# Altium schematic sheet Sheet2.SchDoc
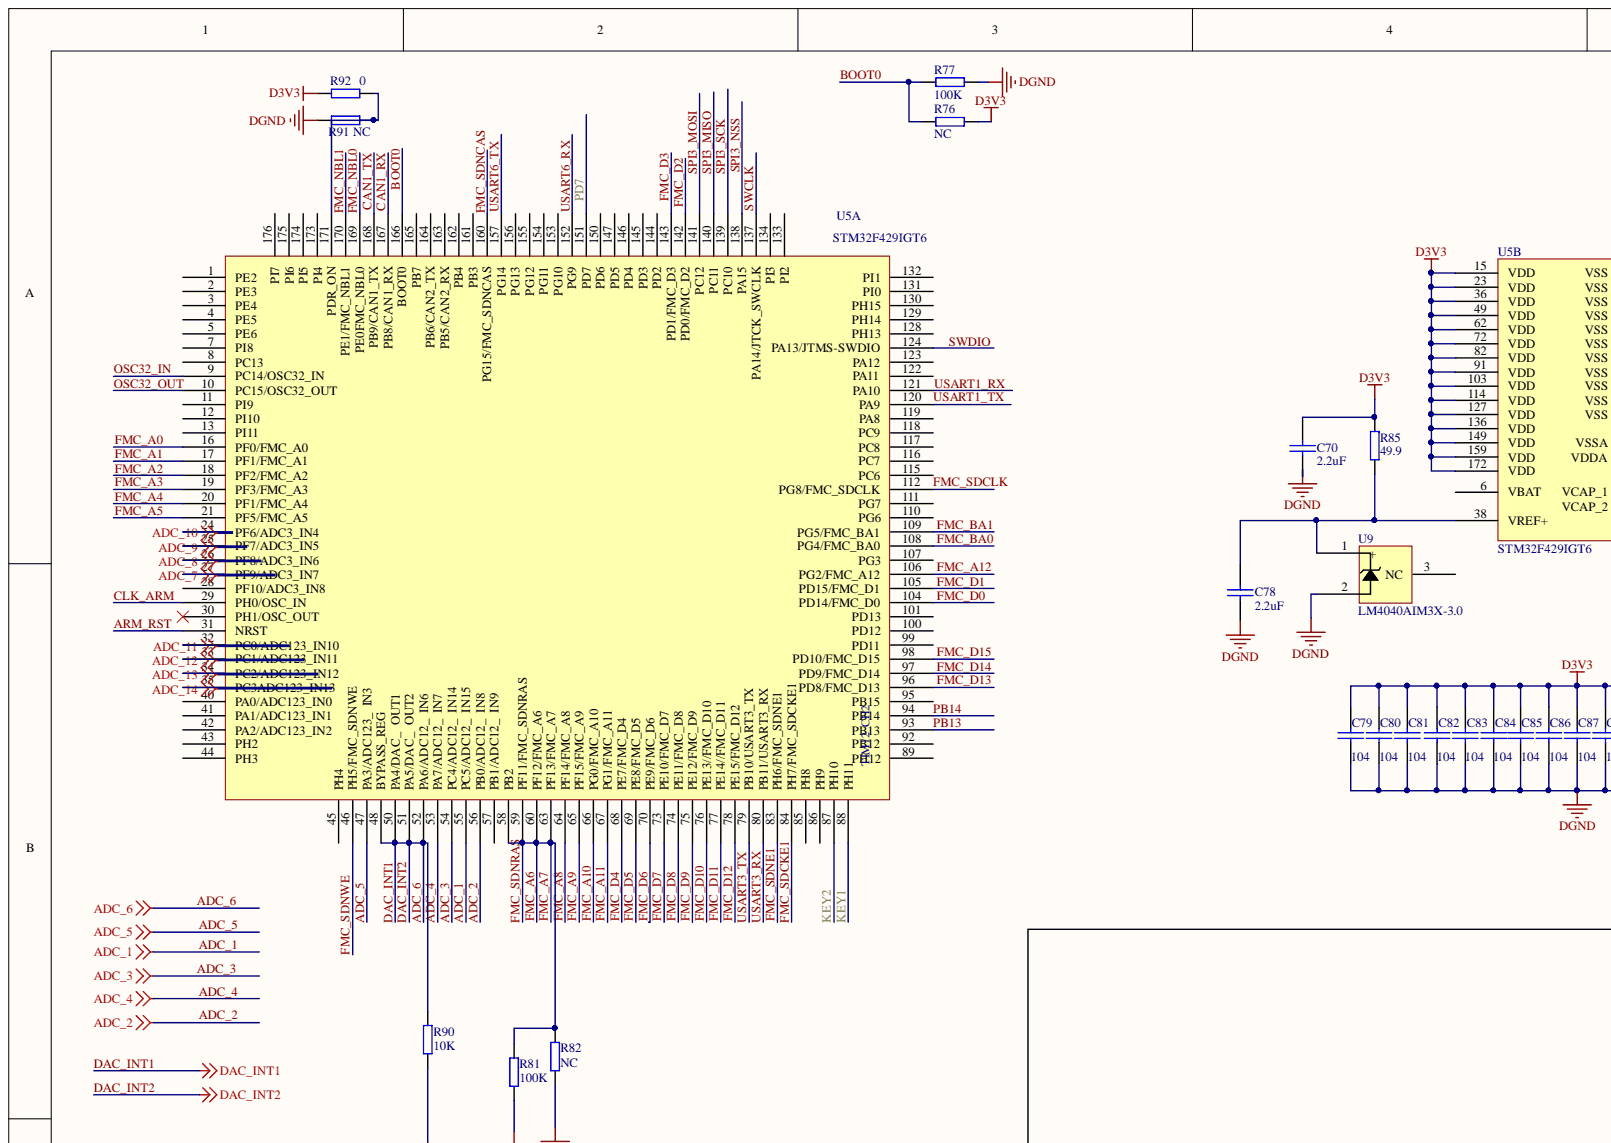
[diagram p0: Sheet2.SchDoc, top left]
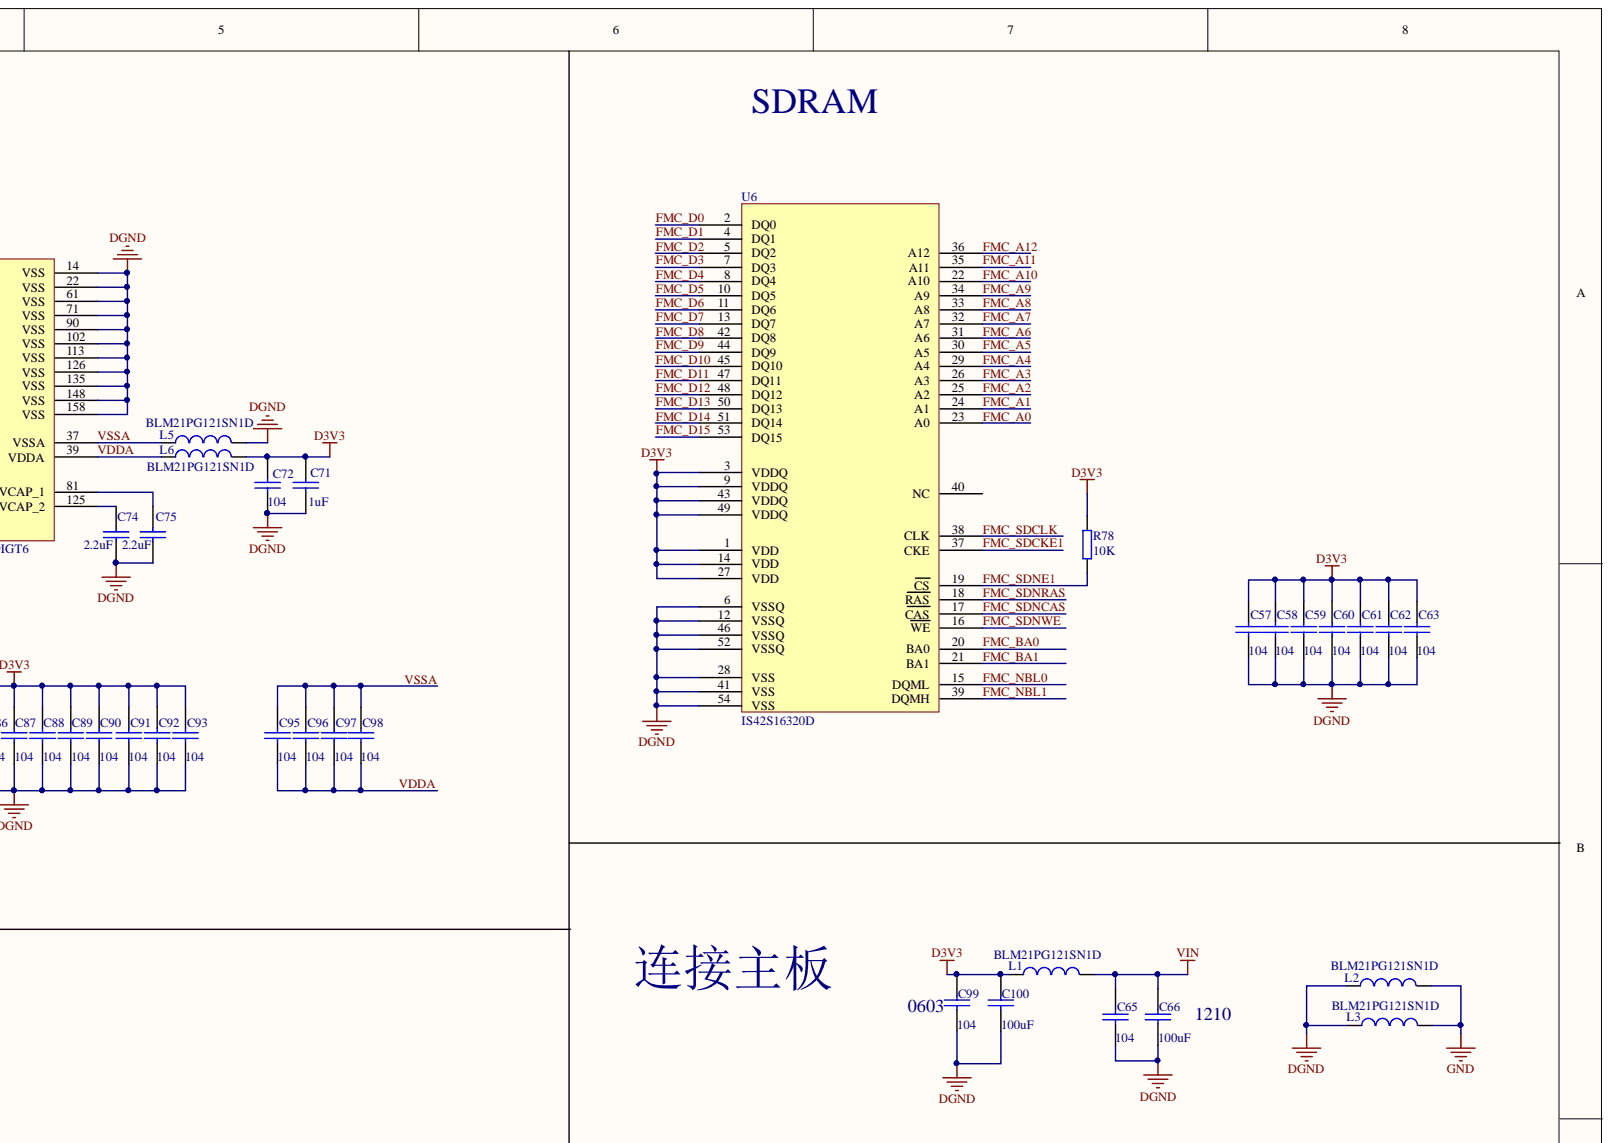
[diagram p1: Sheet2.SchDoc, top right]
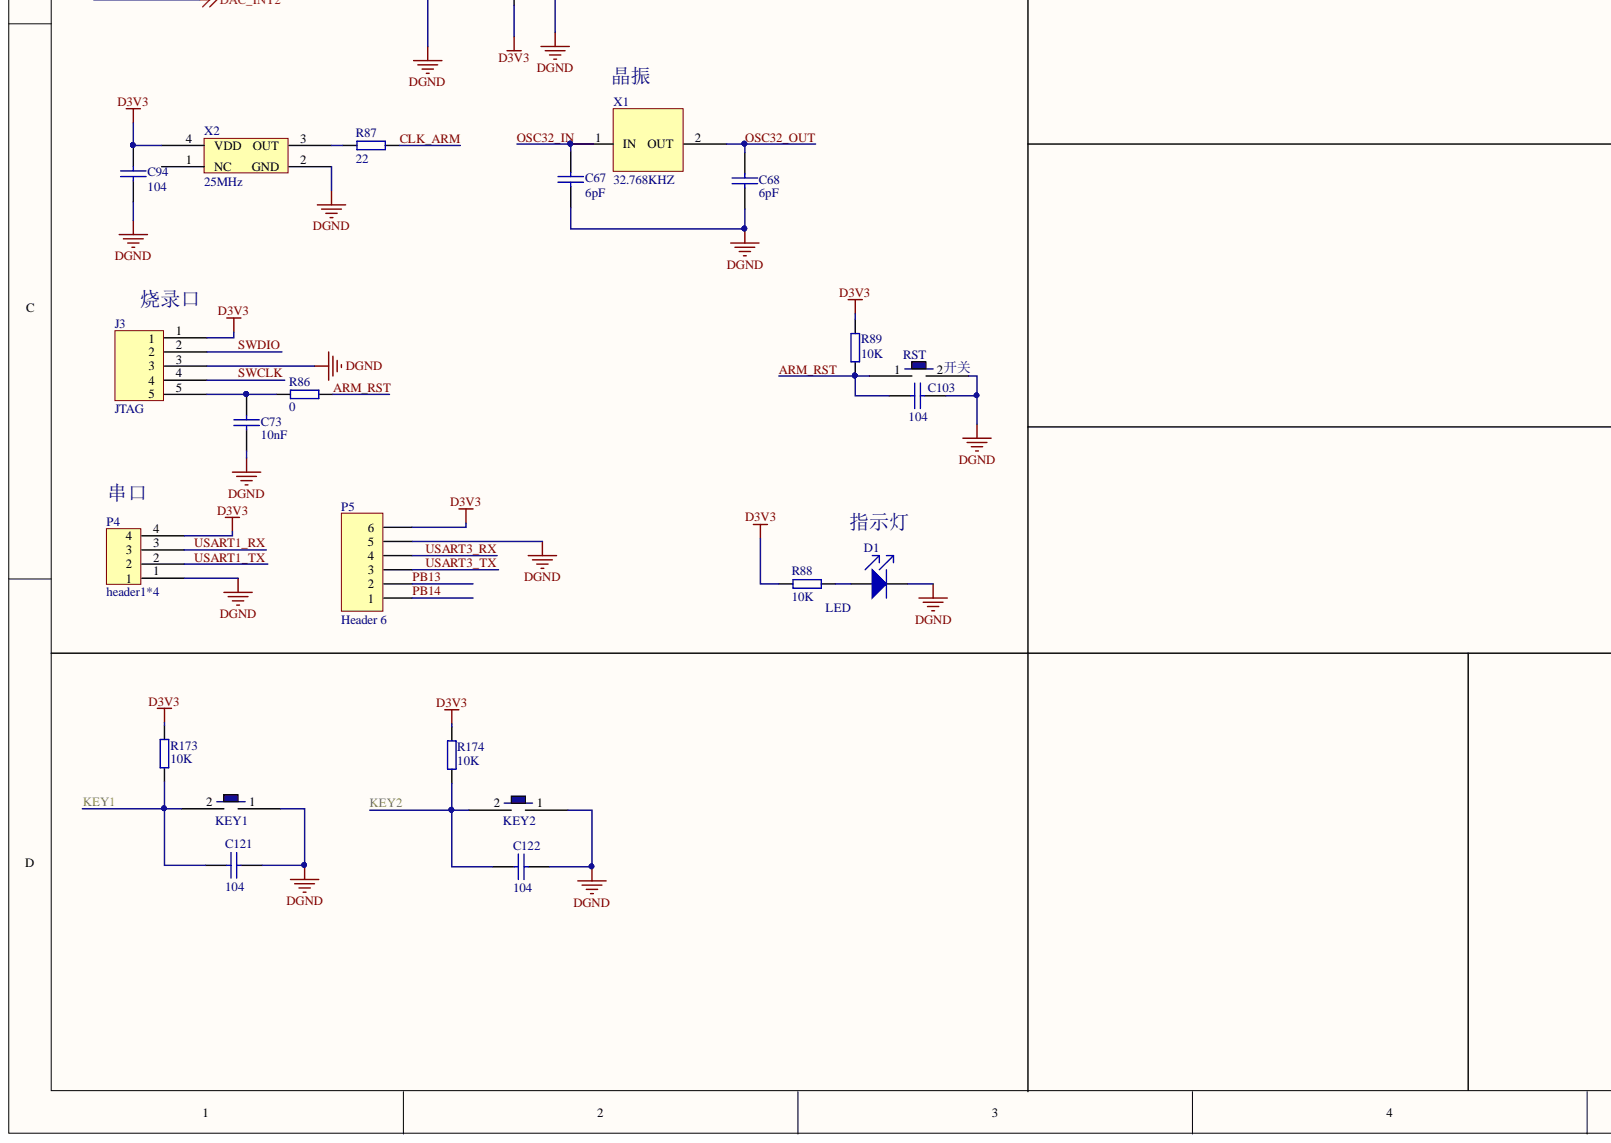
[diagram p2: Sheet2.SchDoc, bottom left]
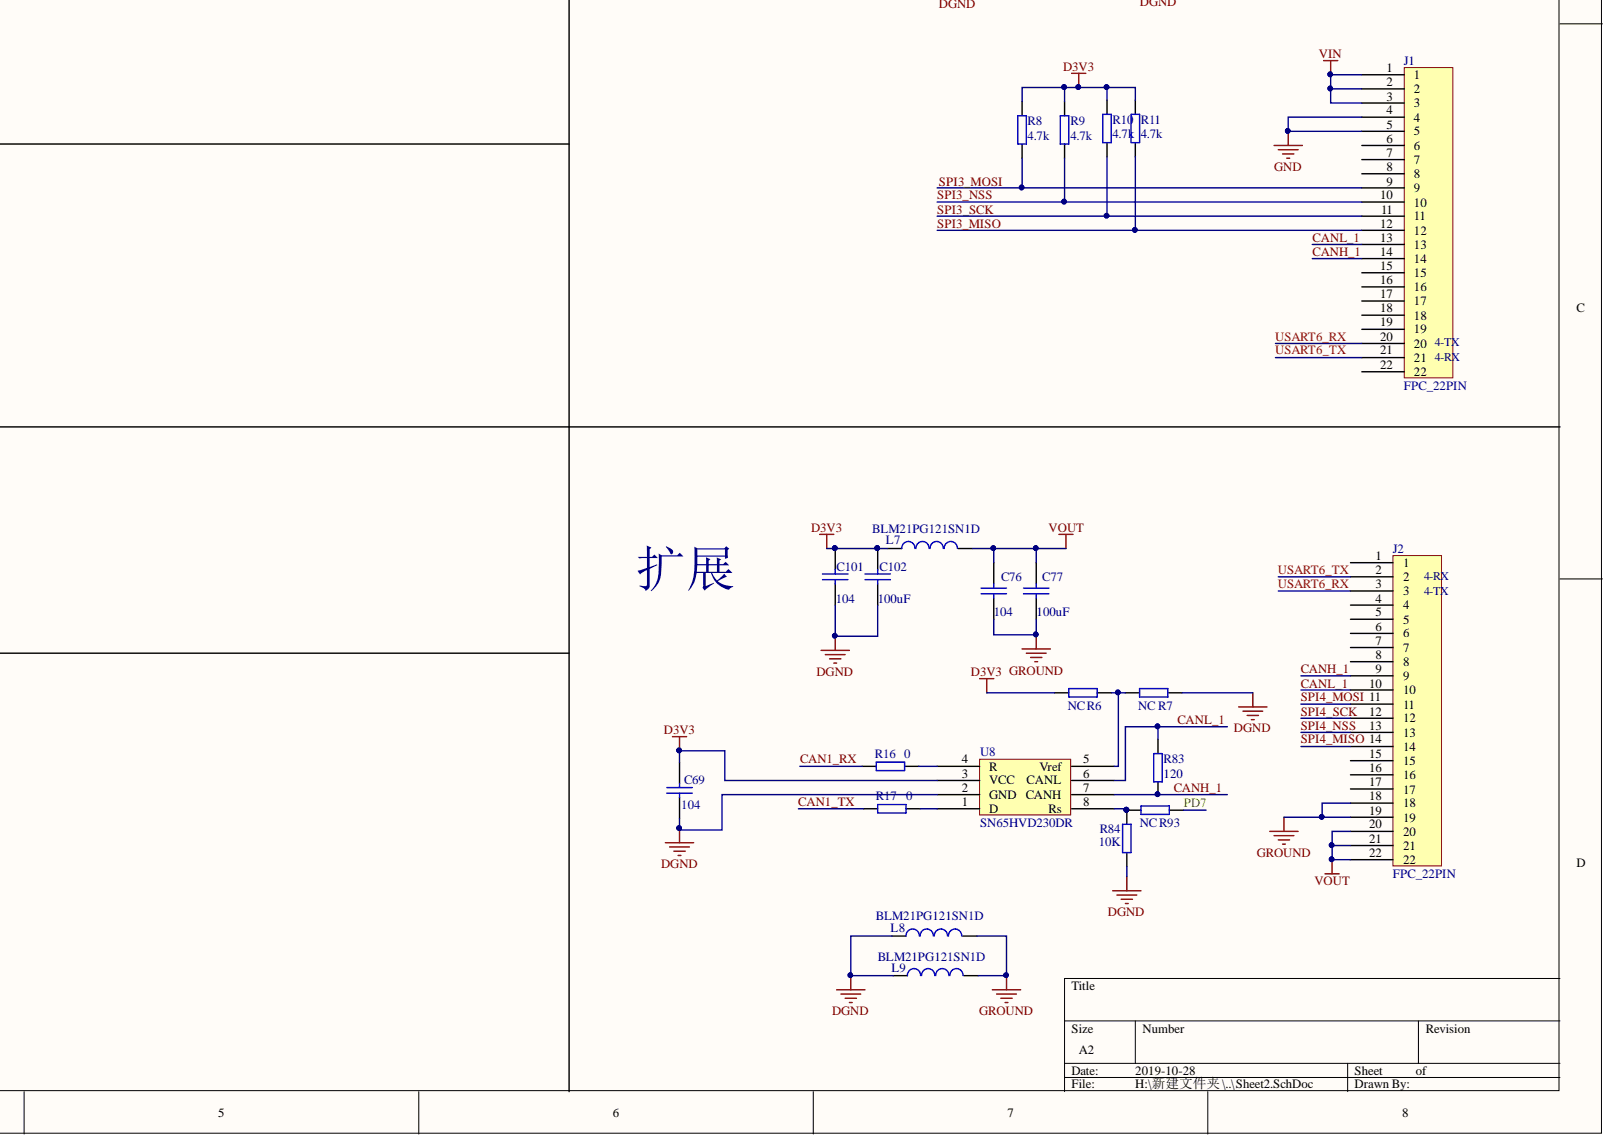
[diagram p3: Sheet2.SchDoc, bottom right]

## Parts
C57  104  [0402_b]
C58  104  [0402_b]
C59  104  [0402_b]
C60  104  [0402_b]
C61  104  [0402_b]
C62  104  [0402_b]
C63  104  [0402_b]
C65  104  [0805]
C66  100uF  [1210]
C67  6pF  [0402_b]
C68  6pF  [0402_b]
C69  104  [0402_b]
C70  2.2uF  [0402_b]
C71  1uF  [0402_b]
C72  104  [0402_b]
C73  10nF  [0402_b]
C74  2.2uF  [0402_b]
C75  2.2uF  [0402_b]
C76  104  [0805]
C77  100uF  [1210]
C78  2.2uF  [0402_b]
C79  104  [0402_b]
C80  104  [0402_b]
C81  104  [0402_b]
C82  104  [0402_b]
C83  104  [0402_b]
C84  104  [0402_b]
C85  104  [0402_b]
C86  104  [0402_b]
C87  104  [0402_b]
C88  104  [0402_b]
C89  104  [0402_b]
C90  104  [0402_b]
C91  104  [0402_b]
C92  104  [0402_b]
C93  104  [0402_b]
C94  104  [0402_b]
C95  104  [0402_b]
C96  104  [0402_b]
C97  104  [0402_b]
C98  104  [0603_b]
C99  104  [0805]
C100  100uF  [1210]
C101  104  [0805]
C102  100uF  [1210]
C103  104  [0402_b]
C121  104  [0402_b]
C122  104  [0402_b]
D1  LED  [0603_b]
J1  FPC_22PIN  [FPC]
J2  FPC_22PIN  [FPC]
J3  JTAG  [XH2.54_5卧插]
KEY1  开关  [key]  (value not drawn)
KEY2  开关  [key]  (value not drawn)
L1  BLM21PG121SN1D  [1231AS-H-100M=P3]
L2  BLM21PG121SN1D  [0805]
L3  BLM21PG121SN1D  [0805]
L5  BLM21PG121SN1D  [0805]
L6  BLM21PG121SN1D  [0805]
L7  BLM21PG121SN1D  [1231AS-H-100M=P3]
L8  BLM21PG121SN1D  [0805]
L9  BLM21PG121SN1D  [0805]
P4  header1*4  [HEADER1-4]
P5  Header 6  [HDR1X6]
R6  NC  [0402_b]
R7  NC  [0402_b]
R8  4.7k  [0402_b]
R9  4.7k  [0402_b]
R10  4.7k  [0402_b]
R11  4.7k  [0402_b]
R16  0  [0402_b]
R17  0  [0402_b]
R76  NC  [0402_b]
R77  100K  [0402_b]
R78  10K  [0402_b]
R81  100K  [0402_b]
R82  NC  [0402_b]
R83  120  [0402_b]
R84  10K  [0402_b]
R85  49.9  [0402_b]
R86  0  [0402_b]
R87  22  [0402_b]
R88  10K  [0402_b]
R89  10K  [0402_b]
R90  10K  [0402_b]
R91  NC  [0402_b]
R92  0  [0402_b]
R93  NC  [0402_b]
R173  10K  [0402_b]
R174  10K  [0402_b]
RST  开关  [key]
U5  STM32F429IGT6  [LQFP176 - duplicate]  (parts A,B)
U6  IS42S16320D  [TSOP54]
U8  SN65HVD230DR  [SOP8]
U9  LM4040AIM3X-3.0  [SOT23_3PIN]
X1  32.768KHZ  [1210_B]
X2  25MHz  [XTL_4PIN_5032]

## Nets
ADC_7: U5.27(PF9/ADC3_IN7)
ADC_8: U5.26(PF8/ADC3_IN6)
ADC_9: U5.25(PF7/ADC3_IN5)
ADC_10: U5.24(PF6/ADC3_IN4)
ADC_11: U5.32(PC0/ADC123_IN10)
ADC_12: U5.33(PC1/ADC123_IN11)
ADC_13: U5.34(PC2/ADC123_IN12)
ADC_14: U5.35(PC3ADC123_IN13)
D3V3: C57.2 C58.2 C59.2 C60.2 C61.2 C62.2 C63.2 C69.2 C70.2 C71.2 C72.2 C79.2 C80.2 C81.2 C82.2 C83.2 C84.2 C85.2 C86.2 C87.2 C88.2 C89.2 C90.2 C91.2 C92.2 C93.2 C94.2 C99.2 C100.2 C101.2 C102.2 J3.1 L1.1 L6.2 L7.1 P4.4 P5.6 R6.2 R8.2 R9.2 R10.2 R11.2 R76.1 R78.1 R81.2 R85.1 R88.2 R89.1 R92.2 R173.1 R174.1 U5.15(VDD) U5.23(VDD) U5.36(VDD) U5.49(VDD) U5.62(VDD) U5.72(VDD) U5.82(VDD) U5.91(VDD) U5.103(VDD) U5.114(VDD) U5.127(VDD) U5.136(VDD) U5.149(VDD) U5.159(VDD) U5.172(VDD) U6.1(VDD) U6.3(VDDQ) U6.9(VDDQ) U6.14(VDD) U6.27(VDD) U6.43(VDDQ) U6.49(VDDQ) U8.3(VCC) X2.4(VDD)
DGND: C57.1 C58.1 C59.1 C60.1 C61.1 C62.1 C63.1 C65.1 C66.1 C67.1 C68.1 C69.1 C70.1 C71.1 C72.1 C73.1 C74.1 C75.1 C78.1 C79.1 C80.1 C81.1 C82.1 C83.1 C84.1 C85.1 C86.1 C87.1 C88.1 C89.1 C90.1 C91.1 C92.1 C93.1 C94.1 C99.1 C100.1 C101.1 C102.1 C103.2 C121.1 C122.1 D1.2 J3.3 KEY1.1 KEY2.1 L2.1 L3.1 L5.2 L8.1 L9.1 P4.1 P5.5 R7.1 R77.1 R82.2 R84.2 R90.2 R91.2 RST.2 U5.14(VSS) U5.22(VSS) U5.61(VSS) U5.71(VSS) U5.90(VSS) U5.102(VSS) U5.113(VSS) U5.126(VSS) U5.135(VSS) U5.148(VSS) U5.158(VSS) U6.6(VSSQ) U6.12(VSSQ) U6.28(VSS) U6.41(VSS) U6.46(VSSQ) U6.52(VSSQ) U6.54(VSS) U8.2(GND) U9.2 X2.2(GND)
GND: J1.4 J1.5 L2.2 L3.2
GROUND: C76.1 C77.1 J2.18 J2.19 L8.2 L9.2
VIN: C65.2 C66.2 J1.1 J1.2 J1.3 L1.2
VOUT: C76.2 C77.2 J2.20 J2.21 J2.22 L7.2
ADC_1: U5.55(PC5/ADC12_)
ADC_2: U5.56
ADC_3: U5.54(PC4/ADC12_)
ADC_4: U5.53(PA7/ADC12_)
ADC_5: U5.47(PA3/ADC123_)
ADC_6: R90.1 U5.48(BYPASS_REG) U5.50(PA4/DAC_) U5.51(PA5/DAC_) U5.52(PA6/ADC12_)
ARM_RST: C103.1 R86.1 R89.2 RST.1 U5.31(NRST)
BOOT0: R76.2 R77.2 U5.166(BOOT0)
CAN1_RX: R16.2 U5.167(PB8/CAN1_RX)
CAN1_TX: R17.2 U5.168(PB9/CAN1_TX)
CANH_1: J1.14 J2.9 R83.2 U8.7(CANH)
CANL_1: J1.13 J2.10 R83.1 U8.6(CANL)
CLK_ARM: R87.1 U5.29(PH0/OSC_IN)
FMC_A0: U5.16(PF0/FMC_A0) U6.23(A0)
FMC_A1: U5.17(PF1/FMC_A1) U6.24(A1)
FMC_A2: U5.18(PF2/FMC_A2) U6.25(A2)
FMC_A3: U5.19(PF3/FMC_A3) U6.26(A3)
FMC_A4: U5.20(PF1/FMC_A4) U6.29(A4)
FMC_A5: U5.21(PF5/FMC_A5) U6.30(A5)
FMC_A6: R81.1 R82.1 U5.58(PB2) U5.59(PF11/FMC_SDNRAS) U5.60(PF12/FMC_A6) U5.63(PF13/FMC_A7) U6.18(RAS) U6.31(A6) U6.32(A7)
FMC_A8: U5.64(PF14/FMC_A8) U6.33(A8)
FMC_A9: U5.65(PF15/FMC_A9) U6.34(A9)
FMC_A10: U5.66(PG0/FMC_A10) U6.22(A10)
FMC_A11: U5.67(PG1/FMC_A11) U6.35(A11)
FMC_A12: U5.106(PG2/FMC_A12) U6.36(A12)
FMC_BA0: U5.108(PG4/FMC_BA0) U6.20(BA0)
FMC_BA1: U5.109(PG5/FMC_BA1) U6.21(BA1)
FMC_D0: U5.104(PD14/FMC_D0) U6.2(DQ0)
FMC_D1: U5.105(PD15/FMC_D1) U6.4(DQ1)
FMC_D2: U5.142(PD0/FMC_D2) U6.5(DQ2)
FMC_D3: U5.143(PD1/FMC_D3) U6.7(DQ3)
FMC_D4: U5.68(PE7/FMC_D4) U6.8(DQ4)
FMC_D5: U5.69(PE8/FMC_D5) U6.10(DQ5)
FMC_D6: U5.70(PE9/FMC_D6) U6.11(DQ6)
FMC_D7: U5.73(PE10/FMC_D7) U6.13(DQ7)
FMC_D8: U5.74(PE11/FMC_D8) U6.42(DQ8)
FMC_D9: U5.75(PE12/FMC_D9) U6.44(DQ9)
FMC_D10: U5.76(PE13//FMC_D10) U6.45(DQ10)
FMC_D11: U5.77(PE14//FMC_D11) U6.47(DQ11)
FMC_D12: U5.78(PE15/FMC_D12) U6.48(DQ12)
FMC_D13: U5.96(PD8/FMC_D13) U6.50(DQ13)
FMC_D14: U5.97(PD9/FMC_D14) U6.51(DQ14)
FMC_D15: U5.98(PD10/FMC_D15) U6.53(DQ15)
FMC_NBL0: U5.169(PE0FMC_NBL0) U6.15(DQML)
FMC_NBL1: U5.170(PE1/FMC_NBL1) U6.39(DQMH)
FMC_SDCKE1: U5.84(PH7/FMC_SDCKE1) U6.37(CKE)
FMC_SDCLK: U5.112(PG8/FMC_SDCLK) U6.38(CLK)
FMC_SDNCAS: U5.160(PG15/FMC_SDNCAS) U6.17(CAS)
FMC_SDNE1: R78.2 U5.83(PH6/FMC_SDNE1) U6.19(CS)
FMC_SDNWE: U5.46(PH5/FMC_SDNWE) U6.16(WE)
KEY1: C121.2 KEY1.2 R173.2 U5.88(PH11)
KEY2: C122.2 KEY2.2 R174.2 U5.87(PH10)
OSC32_IN: C67.2 U5.9(PC14/OSC32_IN) X1.1
OSC32_OUT: C68.2 U5.10(PC15/OSC32_OUT) X1.2
PB13: P5.2 U5.93(PB13)
PB14: P5.1 U5.94(PB14)
PD7: R93.1 U5.151(PD7)
SPI3_MISO: J1.12 R11.1 U5.140(PC11)
SPI3_MOSI: J1.9 R8.1 U5.141(PC12)
SPI3_NSS: J1.10 R9.1 U5.138(PA15)
SPI3_SCK: J1.11 R10.1 U5.139(PC10)
SPI4_MISO: J2.14
SPI4_MOSI: J2.11
SPI4_NSS: J2.13
SPI4_SCK: J2.12
SWCLK: J3.4 U5.137(PA14/JTCK_SWCLK)
SWDIO: J3.2 U5.124(PA13/JTMS-SWDIO)
USART1_RX: P4.3 U5.121(PA10)
USART1_TX: P4.2 U5.120(PA9)
USART3_RX: P5.4 U5.80(PB11/USART3_RX)
USART3_TX: P5.3 U5.79(PB10/USART3_TX)
USART6_RX: J1.20 J2.3 U5.152(PG9)
USART6_TX: J1.21 J2.2 U5.157(PG14)
VDDA: C95.1 C96.1 C97.1 C98.1 L6.1 U5.39(VDDA)
VSSA: C95.2 C96.2 C97.2 C98.2 L5.1 U5.37(VSSA)
NetC73_2: C73.2 J3.5 R86.2
NetC74_2: C74.2 U5.125(VCAP_2)
NetC75_2: C75.2 U5.81(VCAP_1)
NetC78_2: C78.2 R85.2 U5.38(VREF+) U9.1
NetD1_1: D1.1 R88.1
NetR6_1: R6.1 R7.2 U8.5(Vref)
NetR16_1: R16.1 U8.4(R)
NetR17_1: R17.1 U8.1(D)
NetR84_1: R84.1 R93.2 U8.8(Rs)
NetR87_2: R87.2 X2.3(OUT)
NetR91_1: R91.1 R92.1 U5.171(PDR_ON)

Not connected: J1 6 7 8 15 16 17 18 19 22; J2 1 4 5 6 7 8 15 16 17; U5 1(PE2) 2(PE3) 3(PE4) 4(PE5) 5(PE6) 6(VBAT) 7(PI8) 8(PC13) 11(PI9) 12(PI10) 13(PI11) 28(PF10/ADC3_IN8) 30(PH1/OSC_OUT) 40(PA0/ADC123_IN0) 41(PA1/ADC123_IN1) 42(PA2/ADC123_IN2) 43(PH2) 44(PH3) 45(PH4) 57(PB1/ADC12_) 85(PH8) 86(PH9) 89(PH12) 92(PB12) 95(PB15) 99(PD11) 100(PD12) 101(PD13) 107(PG3) 110(PG6) 111(PG7) 115(PC6) 116(PC7) 117(PC8) 118(PC9) 119(PA8) 122(PA11) 123(PA12) 128(PH13) 129(PH14) 130(PH15) 131(PI0) 132(PI1) 133(PI2) 134(PI3) 144(PD2) 145(PD3) 146(PD4) 147(PD5) 150(PD6) 153(PG10) 154(PG11) 155(PG12) 156(PG13) 161(PB3) 162(PB4) 163(PB5/CAN2_RX) 164(PB6/CAN2_TX) 165(PB7) 173(PI4) 174(PI5) 175(PI6) 176(PI7); U6 40(NC); U9 3; X2 1(NC)

## Library data of the parts
C57-C63 C65-C103 C121 C122 = CAP: Component Kind: Standard; Library Name: 战备元件库.SchLib
D1 = 发光二极管: Component Kind: Standard; Library Name: 战备元件库.SchLib
L1-L3 L5-L9 = Inductor: Code_IEC: 1005; Value: 10mH; Code_JEITA: 0402; Component Kind: Standard; Description: Inductor; LatestRevisionDate: 29-May-2009; LatestRevisionNote: IPC-7351 Footprint Added.; Library Name: Miscellaneous Devices.IntLib; PackageDescription: Chip Inductor; PackageReference: 0402-A; Published: 8-Jun-2000; Signal Integrity: Inductor; Simulation: INDUCTOR; Publisher: Altium Limited
P5 = Header 6: Header, 6-Pin; Component Kind: Standard; LatestRevisionDate: 17-Jul-2002; LatestRevisionNote: Re-released for DXP Platform.; Library Name: Miscellaneous Connectors.IntLib; Signal Integrity: Connector; Publisher: Altium Limited
R6-R11 R16 R17 R76-R78 R81-R93 R173 R174 = RES: Component Kind: Standard; Library Name: 战备元件库.SchLib
X1 = U_XTAL: Component Kind: Standard; Library Name: 战备元件库.SchLib
X2 = 有源晶振: Component Kind: Standard; Library Name: 战备元件库.SchLib
J1 J2 = FPC_22PIN: Component Kind: Standard; Library Name: 战备元件库.SchLib
J3 = JTAG: Component Kind: Standard; Library Name: 战备元件库.SchLib
KEY1 KEY2 RST = 开关: Component Kind: Standard; Library Name: 战备元件库.SchLib
P4 = header1*4: Component Kind: Standard; Library Name: 战备元件库.SchLib
U5 = STM32F429IGT6: Component Kind: Standard; Library Name: 战备元件库.SchLib
U6 = IS42S16320D: Component Kind: Standard; Library Name: 战备元件库.SchLib
U8 = SN65HVD230DR: Component Kind: Standard; Library Name: 战备元件库.SchLib
U9 = LM4040AIM3X-3.0: Component Kind: Standard; Library Name: 战备元件库.SchLib
